# Altium schematic sheet stmf32.SchDoc
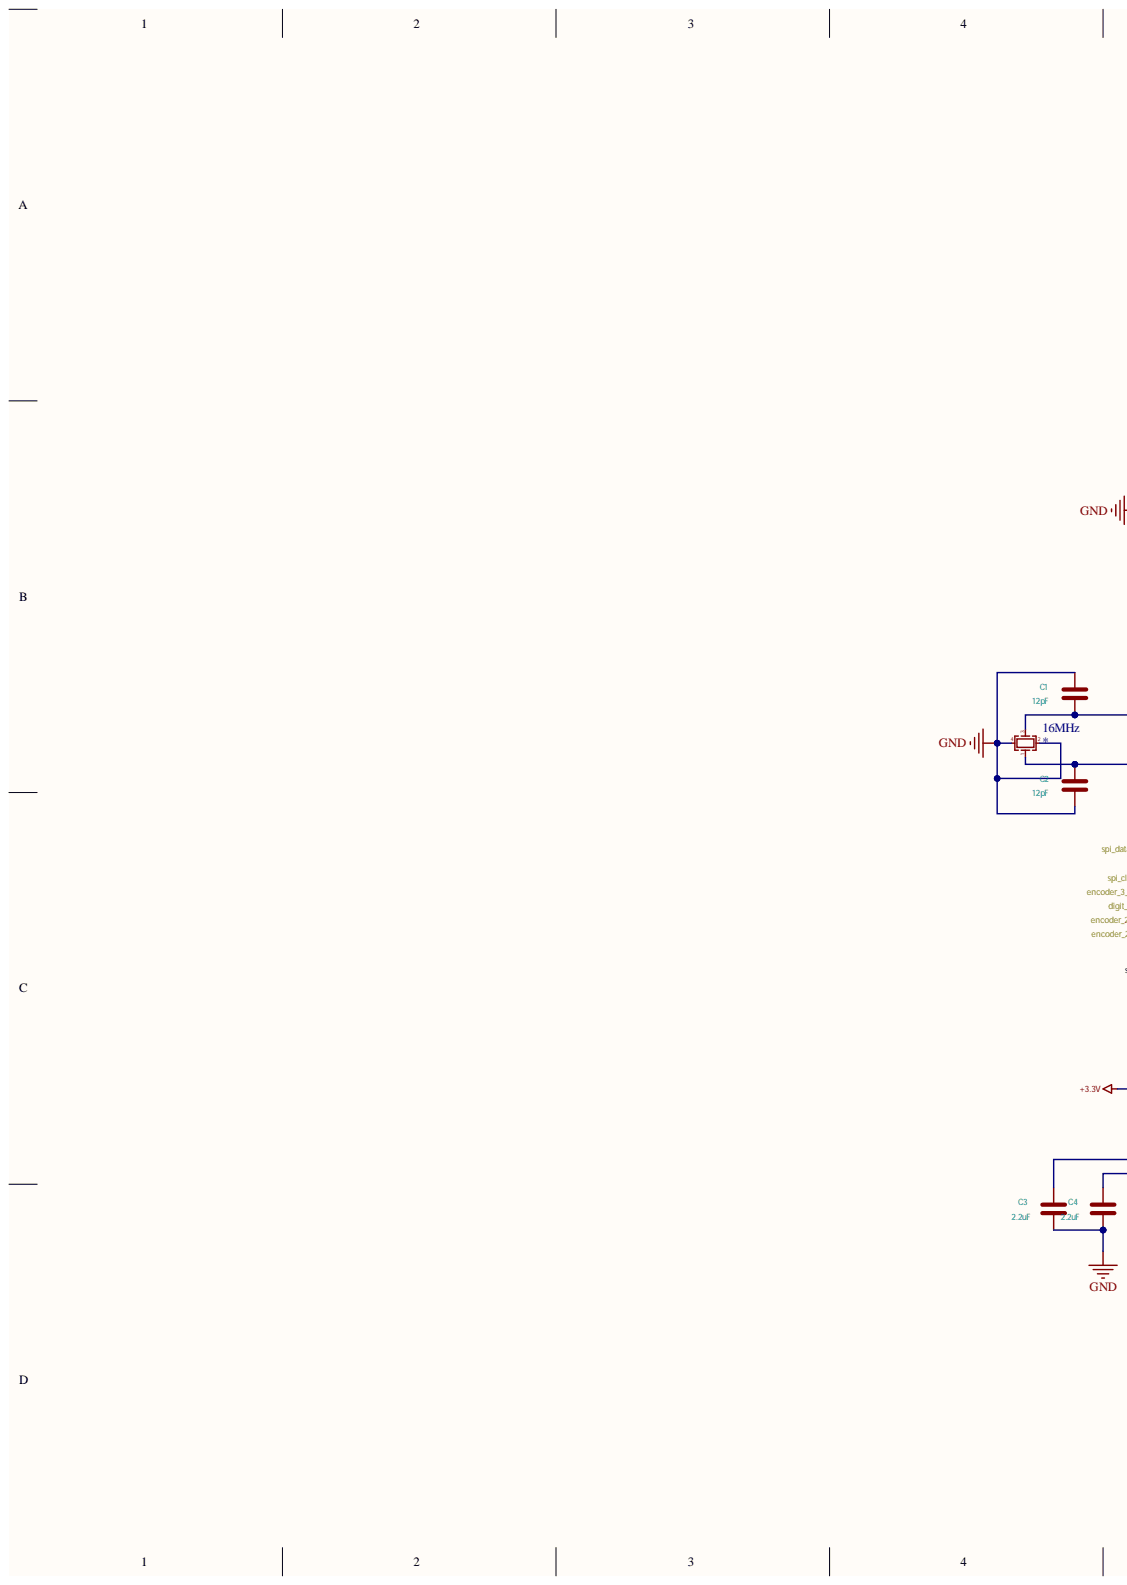
[diagram p0: stmf32.SchDoc, left]
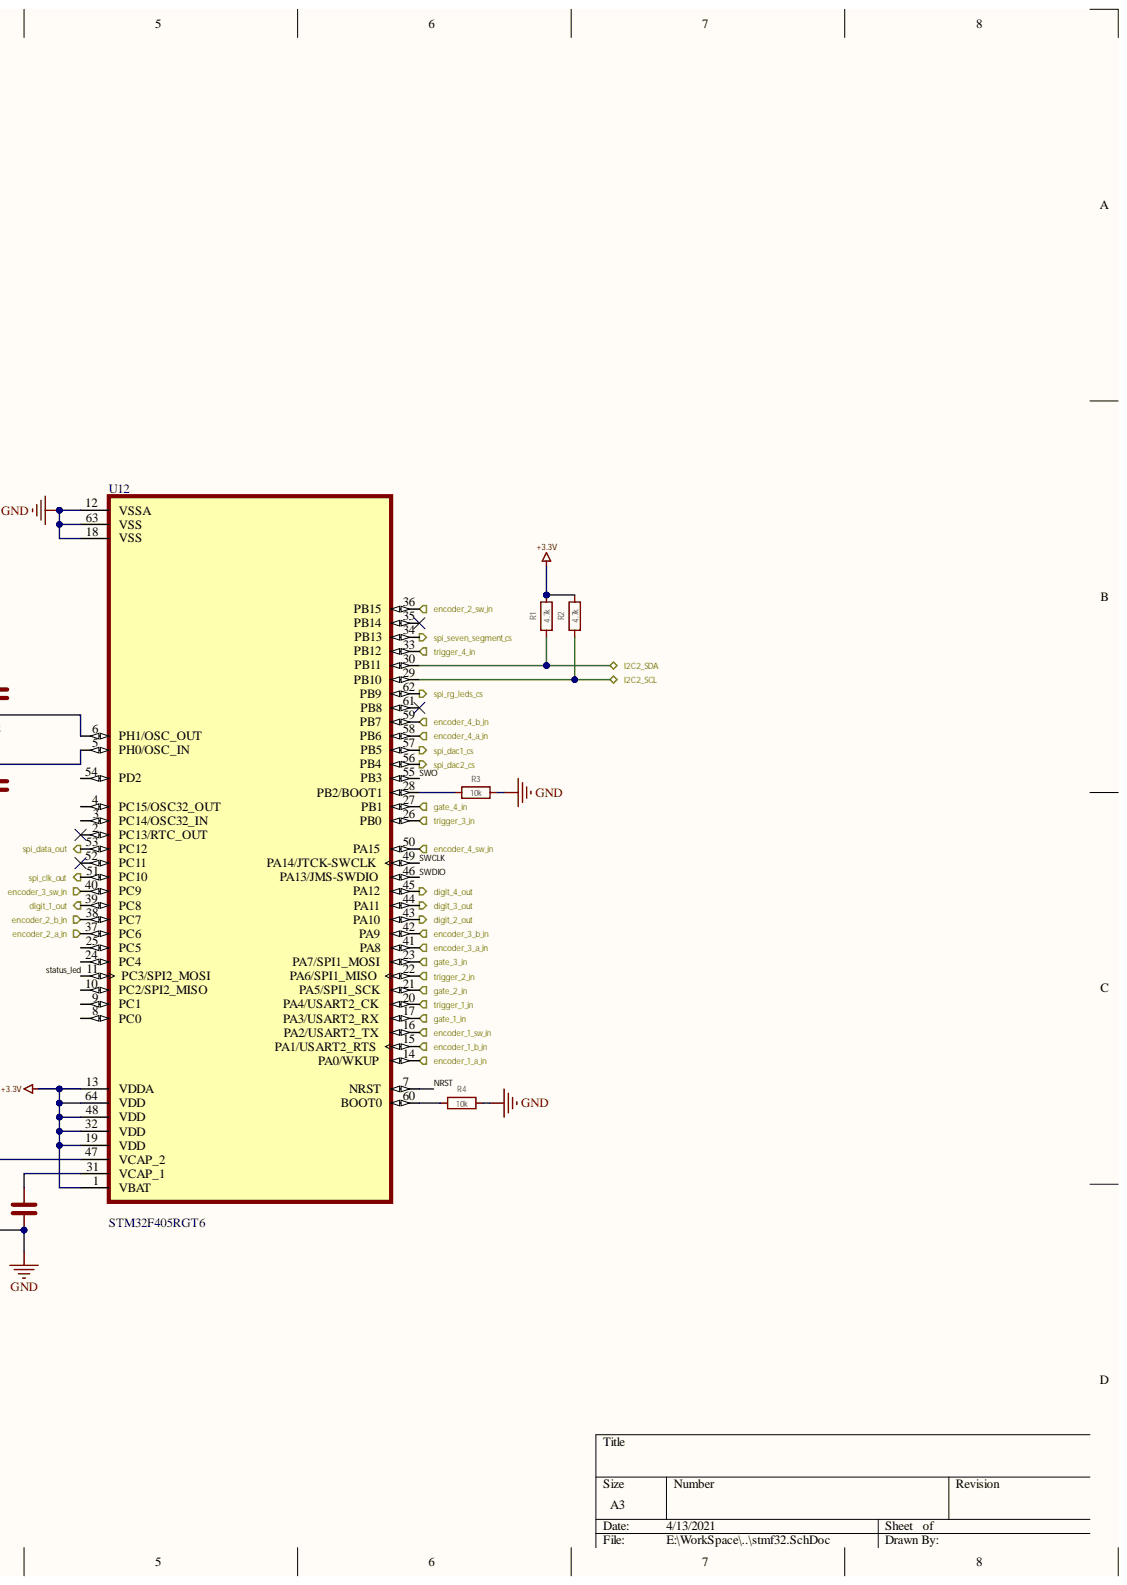
[diagram p1: stmf32.SchDoc, right]

## Parts
16MHz  (no value)
C1  value 12pF  [Capacitor_SMD:C_1206_3216Metric]  (hidden comment: 2.2uF)
C2  value 12pF  [Capacitor_SMD:C_1206_3216Metric]  (hidden comment: 2.2uF)
C3  2.2uF  [Capacitor_SMD:C_1206_3216Metric]
C4  2.2uF  [Capacitor_SMD:C_1206_3216Metric]
R1  value 4.7k  [Resistor_SMD:R_0603_1608Metric]  (hidden comment: 1k)
R2  value 4.7k  [Resistor_SMD:R_0603_1608Metric]  (hidden comment: 1k)
R3  value 10k  [Resistor_SMD:R_0603_1608Metric]  (hidden comment: 1k)
R4  value 10k  [Resistor_SMD:R_0603_1608Metric]  (hidden comment: 1k)
U12  STM32F405RGT6  [QFP50P1200X1200X160-64N]

## Ports
digit_1_out, digit_2_out, digit_3_out, digit_4_out, encoder_1_a_in, encoder_1_b_in, encoder_1_sw_in, encoder_2_a_in, encoder_2_b_in, encoder_2_sw_in, encoder_3_a_in, encoder_3_b_in, encoder_3_sw_in, encoder_4_a_in, encoder_4_b_in, encoder_4_sw_in, gate_1_in, gate_2_in, gate_3_in, gate_4_in, I2C2_SCL, I2C2_SDA, spi_clk_out, spi_dac1_cs, spi_dac2_cs, spi_data_out, spi_rg_leds_cs, spi_seven_segment_cs, trigger_1_in, trigger_2_in, trigger_3_in, trigger_4_in

## Nets
+3.3V: R1.1 R2.1 U12.1(VBAT) U12.13(VDDA) U12.19(VDD) U12.32(VDD) U12.48(VDD) U12.64(VDD)
GND: 16MHz.2 16MHz.4 C1.1 C2.2 C3.2 C4.2 R3.1 R4.1 U12.12(VSSA) U12.18(VSS) U12.63(VSS)
digit_1_out: U12.39(PC8) ; port digit_1_out
digit_2_out: U12.43(PA10) ; port digit_2_out
digit_3_out: U12.44(PA11) ; port digit_3_out
digit_4_out: U12.45(PA12) ; port digit_4_out
encoder_1_a_in: U12.14(PA0/WKUP) ; port encoder_1_a_in
encoder_1_b_in: U12.15(PA1/USART2_RTS) ; port encoder_1_b_in
encoder_1_sw_in: U12.16(PA2/USART2_TX) ; port encoder_1_sw_in
encoder_2_a_in: U12.37(PC6) ; port encoder_2_a_in
encoder_2_b_in: U12.38(PC7) ; port encoder_2_b_in
encoder_2_sw_in: U12.36(PB15) ; port encoder_2_sw_in
encoder_3_a_in: U12.41(PA8) ; port encoder_3_a_in
encoder_3_b_in: U12.42(PA9) ; port encoder_3_b_in
encoder_3_sw_in: U12.40(PC9) ; port encoder_3_sw_in
encoder_4_a_in: U12.58(PB6) ; port encoder_4_a_in
encoder_4_b_in: U12.59(PB7) ; port encoder_4_b_in
encoder_4_sw_in: U12.50(PA15) ; port encoder_4_sw_in
gate_1_in: U12.17(PA3/USART2_RX) ; port gate_1_in
gate_2_in: U12.21(PA5/SPI1_SCK) ; port gate_2_in
gate_3_in: U12.23(PA7/SPI1_MOSI) ; port gate_3_in
gate_4_in: U12.27(PB1) ; port gate_4_in
I2C2_SCL: R2.2 U12.29(PB10) ; port I2C2_SCL
I2C2_SDA: R1.2 U12.30(PB11) ; port I2C2_SDA
NRST: U12.7(NRST)
spi_clk_out: U12.51(PC10) ; port spi_clk_out
spi_dac1_cs: U12.57(PB5) ; port spi_dac1_cs
spi_dac2_cs: U12.56(PB4) ; port spi_dac2_cs
spi_data_out: U12.53(PC12) ; port spi_data_out
spi_rg_leds_cs: U12.62(PB9) ; port spi_rg_leds_cs
spi_seven_segment_cs: U12.34(PB13) ; port spi_seven_segment_cs
status_led: U12.11(PC3/SPI2_MOSI)
SWCLK: U12.49(PA14/JTCK-SWCLK)
SWDIO: U12.46(PA13/JMS-SWDIO)
SWO: U12.55(PB3)
trigger_1_in: U12.20(PA4/USART2_CK) ; port trigger_1_in
trigger_2_in: U12.22(PA6/SPI1_MISO) ; port trigger_2_in
trigger_3_in: U12.26(PB0) ; port trigger_3_in
trigger_4_in: U12.33(PB12) ; port trigger_4_in
Net16MHz_1: 16MHz.1 C2.1 U12.5(PH0/OSC_IN)
Net16MHz_3: 16MHz.3 C1.2 U12.6(PH1/OSC_OUT)
NetC3_1: C3.1 U12.47(VCAP_2)
NetC4_1: C4.1 U12.31(VCAP_1)
NetR3_2: R3.2 U12.28(PB2/BOOT1)
NetR4_2: R4.2 U12.60(BOOT0)

Not connected: U12 2(PC13/RTC_OUT) 3(PC14/OSC32_IN) 4(PC15/OSC32_OUT) 8(PC0) 9(PC1) 10(PC2/SPI2_MISO) 24(PC4) 25(PC5) 35(PB14) 52(PC11) 54(PD2) 61(PB8)

## Library data of the parts
16MHz = Crystal_GND24_Small: Component Kind: Standard; Library Name: Device
C1-C4 = C: Component Kind: Standard; Library Name: Device
  C3 C4: Value: 2.2uF
R1-R4 = R: Component Kind: Standard; Library Name: Device
U12 = STM32F405RGT6: ARMÂ® CortexÂ®-M4 STM32F4 Microcontroller IC 32-Bit 168MHz 1MB _1M x 8_ FLASH 64-LQFP _10x10_; MF: STMicroelectronics; Component Kind: Standard; Digi-Key_Part_Number: 497-11767-ND; Digi-Key_Purchase_URL: https://www.digikey.com/product-detail/en/stmicroelectronics/STM32F405RGT6/497-11767-ND/2754208?utm_source=snapeda&utm_medium=aggregator&utm_campaign=symbol; MP: STM32F405RGT6; Package: LQFP-64 STMicroelectronics; SnapEDA_Link: https://www.snapeda.com/parts/STM32F405RGT6/STMicroelectronics/view-part/105502/?ref=snap
